# Altium schematic sheet Relays.SchDoc(Relays)
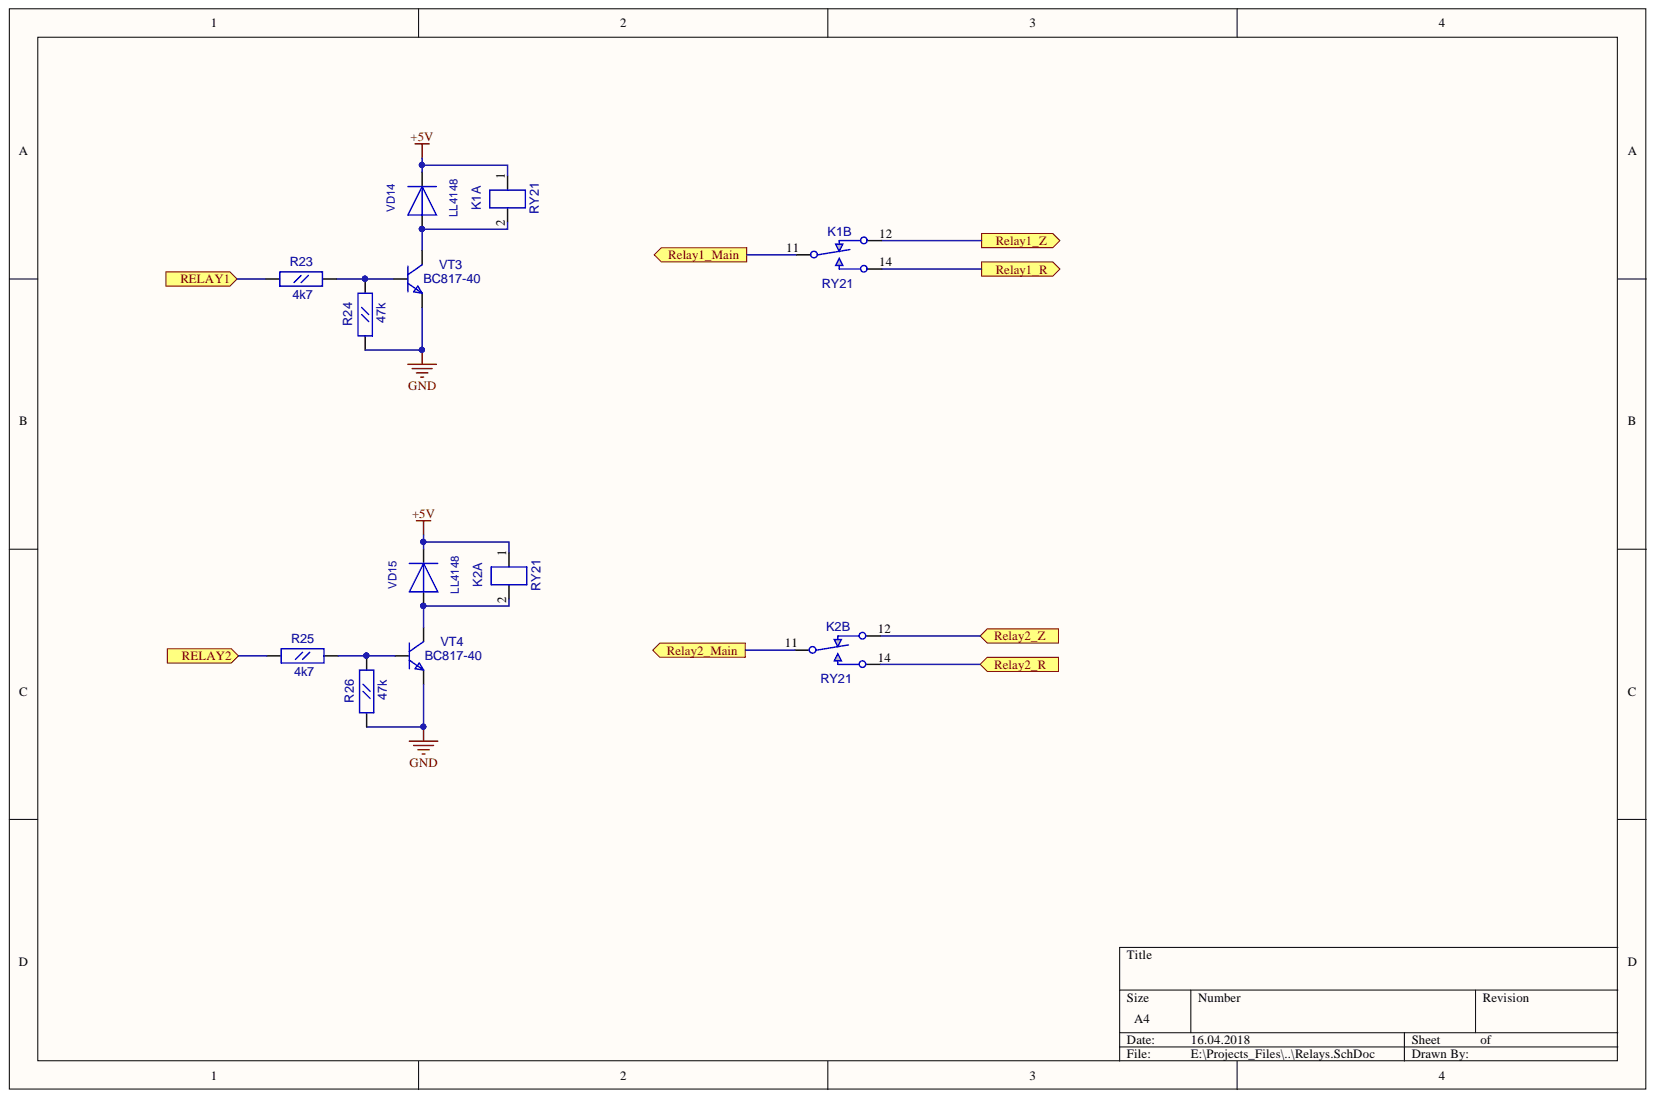
[diagram p0: Relays.SchDoc]

## Parts
K1  RY21  [RY21_RY21(Primary)]  (parts A,B)
K2  RY21  [RY21_RY21(Primary)]  (parts A,B)
R23  4k7  [RC0603]
R24  47k  [RC0603]
R25  4k7  [RC0603]
R26  47k  [RC0603]
VD14  LL4148  [SOD-80]
VD15  LL4148  [SOD-80]
VT3  BC817-40  [SOT-23(123)]
VT4  BC817-40  [SOT-23(123)]

## Ports
RELAY1, Relay1_Main, Relay1_R, Relay1_Z, RELAY2, Relay2_Main, Relay2_R, Relay2_Z

## Nets
+5V: K1.1 K2.1 VD14.2 VD15.2
GND: R24.1 R26.1 VT3.2 VT4.2
RELAY1: R23.1 ; port RELAY1
Relay1_Main: K1.11 ; port Relay1_Main
Relay1_R: K1.14 ; port Relay1_R
Relay1_Z: K1.12 ; port Relay1_Z
RELAY2: R25.1 ; port RELAY2
Relay2_Main: K2.11 ; port Relay2_Main
Relay2_R: K2.14 ; port Relay2_R
Relay2_Z: K2.12 ; port Relay2_Z
NetK1_2: K1.2 VD14.1 VT3.3
NetK2_2: K2.2 VD15.1 VT4.3
NetR23_2: R23.2 R24.2 VT3.1
NetR25_2: R25.2 R26.2 VT4.1

## Library data of the parts
R23-R26 = RC0603: Description: RC0603; Component Kind: Standard; Library Name: gprs_modem_2.SchLib
  R23 R25: Value: 4k7
  R24 R26: Value: 47k
VT3 VT4 = BC846BLT1: Value: {Value}; ComponentField7: Published 29-Sep-2000; Simulation: BC846BLT1; OriginalName: BC846BLT1; Description: BC846BLT1; Component Kind: Standard; Library Name: gprs_modem_2.SchLib
K1 K2 = RY21: Description: RY21; Component Kind: Standard; Library Name: shlagbaum.SchLib
VD14 VD15 = LL4148: Description: LL4148; Component Kind: Standard; Library Name: gprs_modem_2.SchLib
